# Altium schematic sheet DC-Motor.SchDoc
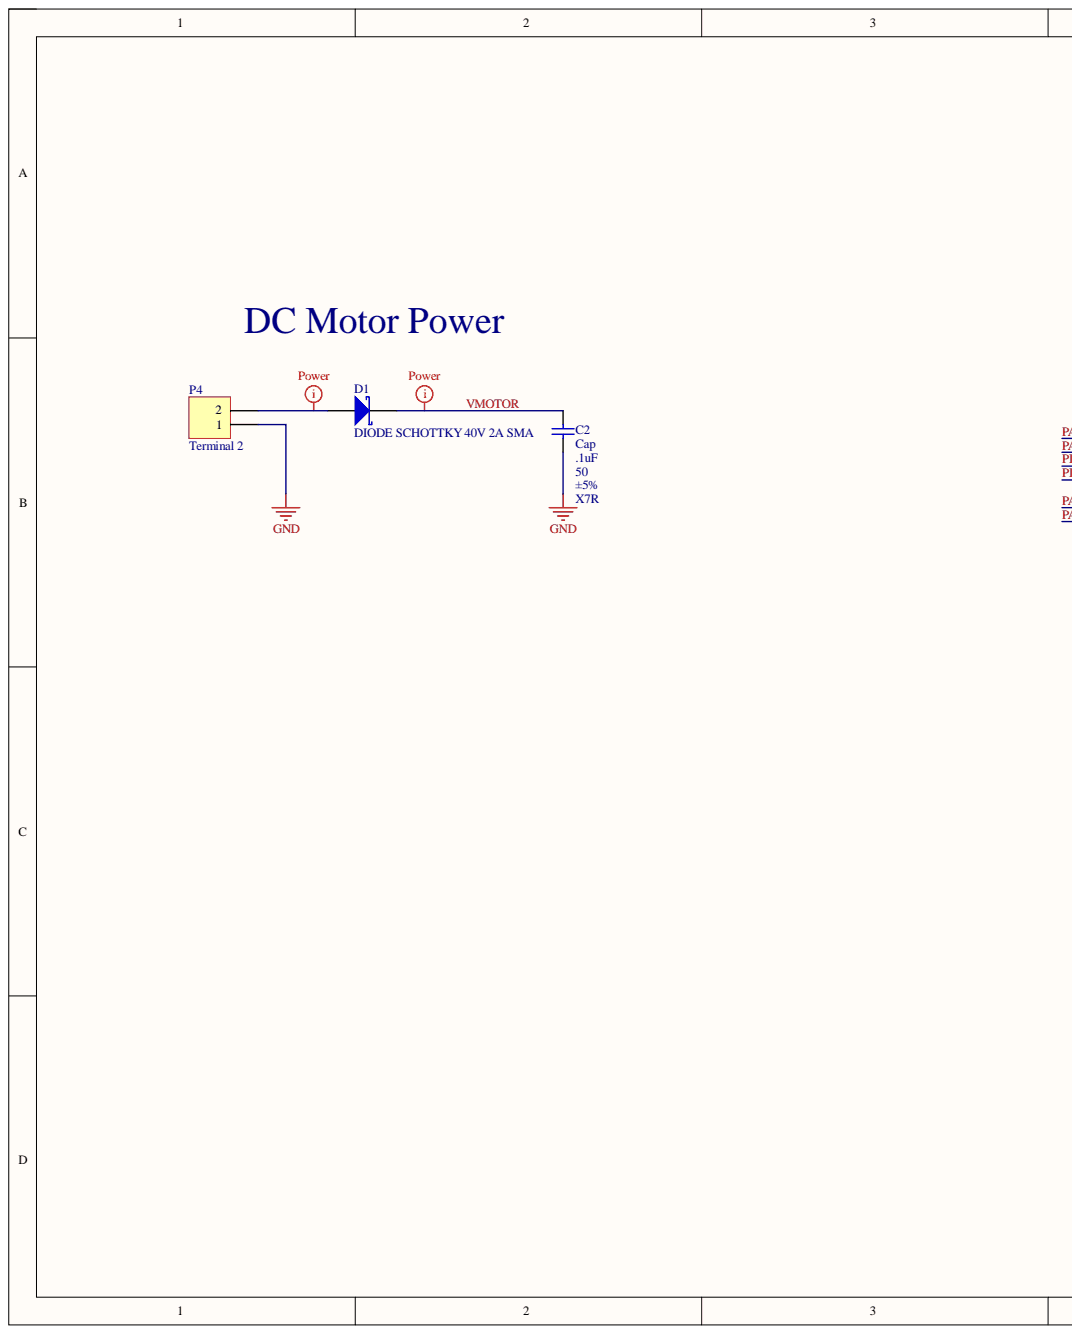
[diagram p0: DC-Motor.SchDoc, left]
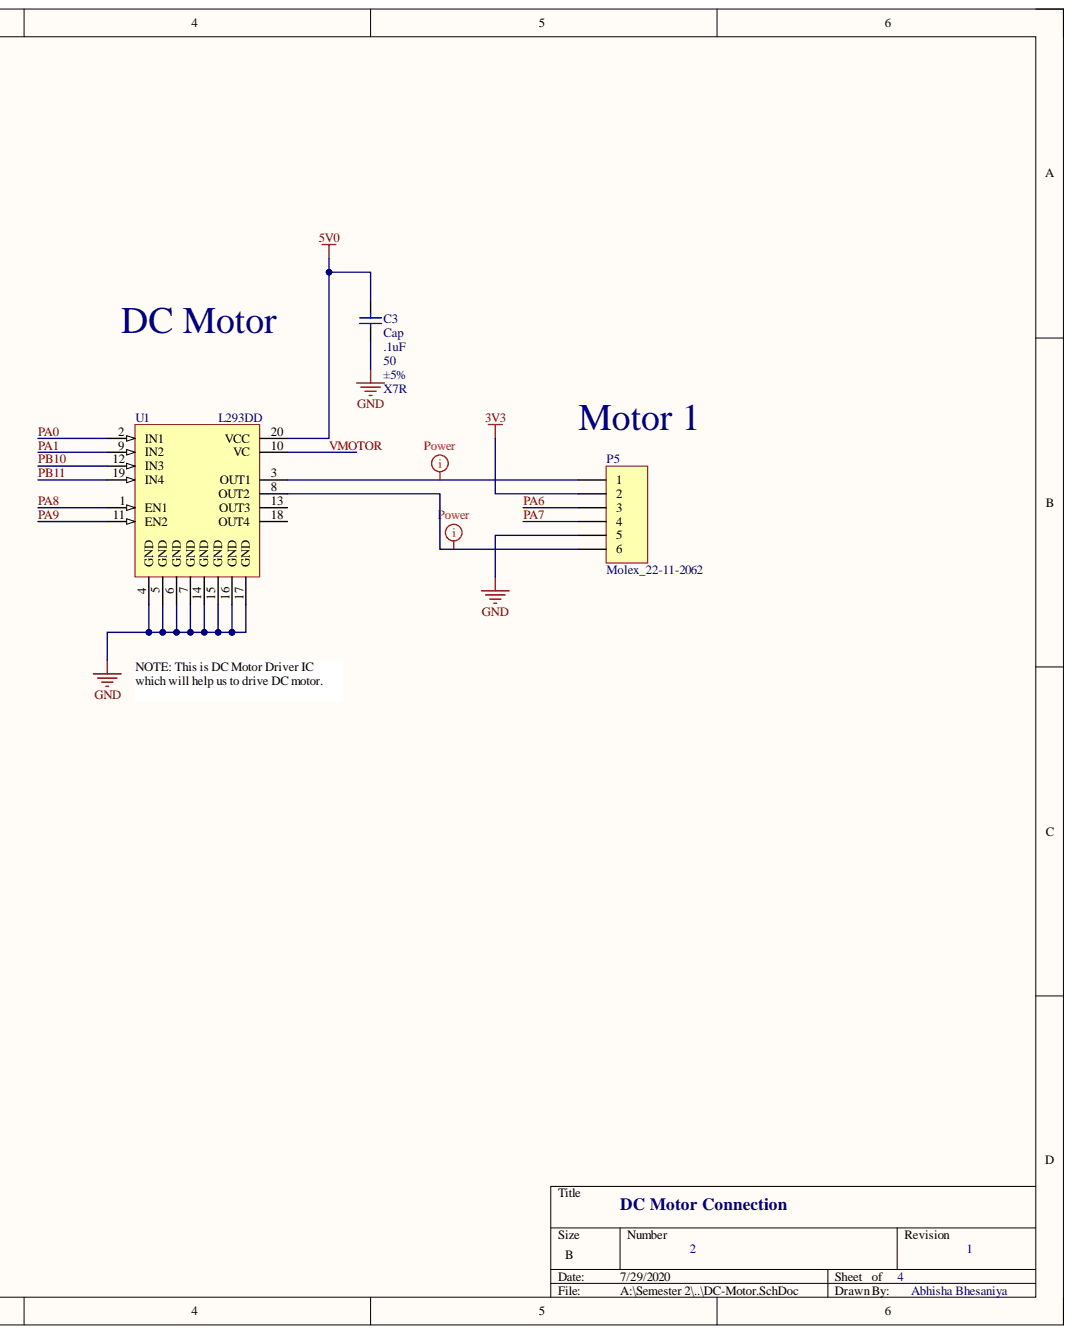
[diagram p1: DC-Motor.SchDoc, right]

## Parts
C2  Cap  value .1uF  Tolerance: ±5%  [CAPC2012X09M]
C3  Cap  value .1uF  Tolerance: ±5%  [CAPC2012X09M]
D1  DIODE SCHOTTKY 40V 2A SMA  [DIOM4326X23M]
P4  Terminal 2  [TYCO_282841_2]
P5  Molex_22-11-2062  [MOLEX_22-11-2062]
U1  L293DD  [SO20_N]

## Nets
3V3: P5.2
5V0: C3.2 U1.20(VCC)
GND: C2.1 C3.1 P4.1 P5.5 U1.4(GND) U1.5(GND) U1.6(GND) U1.7(GND) U1.14(GND) U1.15(GND) U1.16(GND) U1.17(GND)
PA0_2: U1.2(IN1)  [also labelled PA0]
PA1_2: U1.9(IN2)  [also labelled PA1]
PA6_2: P5.3  [also labelled PA6]
PA7_2: P5.4  [also labelled PA7]
PA8_2: U1.1(EN1)  [also labelled PA8]
PA9_2: U1.11(EN2)  [also labelled PA9]
PB10_2: U1.12(IN3)  [also labelled PB10]
PB11_2: U1.19(IN4)  [also labelled PB11]
VMOTOR_2: C2.2 D1.1 U1.10(VC)  [also labelled VMOTOR]
NetD1_2: D1.2 P4.2
NetP5_1: P5.1 U1.3(OUT1)
NetP5_6: P5.6 U1.8(OUT2)

Not connected: U1 13(OUT3) 18(OUT4)

## Library data of the parts
C2 C3 = CAP-X7R-.1uF-50V-±5%: CAP CER .1uF 50v X7R 0805; MPN: C0805C104J5RACTU; PN: 399-1171-1-ND; WV: 50; Component Kind: Standard; Library Name: esd_library.IntLib; Name: CAP-X7R-.1uF-50V-±5%; Type: X7R; URL: http://www.digikey.ca/product-detail/en/C0805C104J5RACTU/399-1171-1-ND
D1 = DIODE SCHOTTKY 40V 2A SMA: Schottky Rectifier; MPN: B240A-13-F; PN: B240A-FDICT-ND; Component Kind: Standard; Library Name: esd_library.IntLib; URL: http://www.digikey.ca/product-detail/en/B240A-13-F/B240A-FDICT-ND
P4 = Terminal 2: Terminal, 2-Pin; MPN: 282841-2; PN: A98342-ND; Component Kind: Standard; LatestRevisionDate: 17-Jul-2002; LatestRevisionNote: Re-released for DXP Platform.; Library Name: esd_library.IntLib; Signal Integrity: Connector; URL: http://www.digikey.ca/product-detail/en/282841-2/A98342-ND/1136740; Publisher: Altium Limited
P5 = Molex_22-11-2062: CONN HEADER 6POS .100 VERT GOLD; MPN: 0022112062; PN: WM2704-ND; Component Kind: Standard; Library Name: esd_library.IntLib; URL: http://www.digikey.ca/product-detail/en/0022112062/WM2704-ND
U1 = L293DD: IC MOTOR DRIVER PAR 20-SOIC; MPN: L293DD013TR; PN: 497-2937-1-ND; Code_IPC: SOIC127P1030-20; Code_JEDEC: MS-013-AC; Component Kind: Standard; ComponentLink1Description: Manufacturer Link; ComponentLink1URL: http://www.st.com/; ComponentLink2Description: Datasheet; ComponentLink2URL: http://www.st.com/stonline/products/literature/ds/1330/l293d.pdf; DatasheetVersion: Jun-1996; LatestRevisionDate: 13-Apr-2006; LatestRevisionNote: IPC-7351 Footprint Added.; Library Name: esd_library.IntLib; PackageDescription: 20-Pin Plastic Small Outline Integrated Circuit 1.27 mm Pitch; PackageReference: SO20; PackageVersion: Jul-2001; PCB3D: SO20; Published: 8-Jun-2000; URL: http://www.digikey.ca/product-detail/en/L293DD013TR/497-2937-1-ND; Publisher: Altium Limited
